# Altium schematic sheet MotorDriver.SchDoc(MotorDriver)
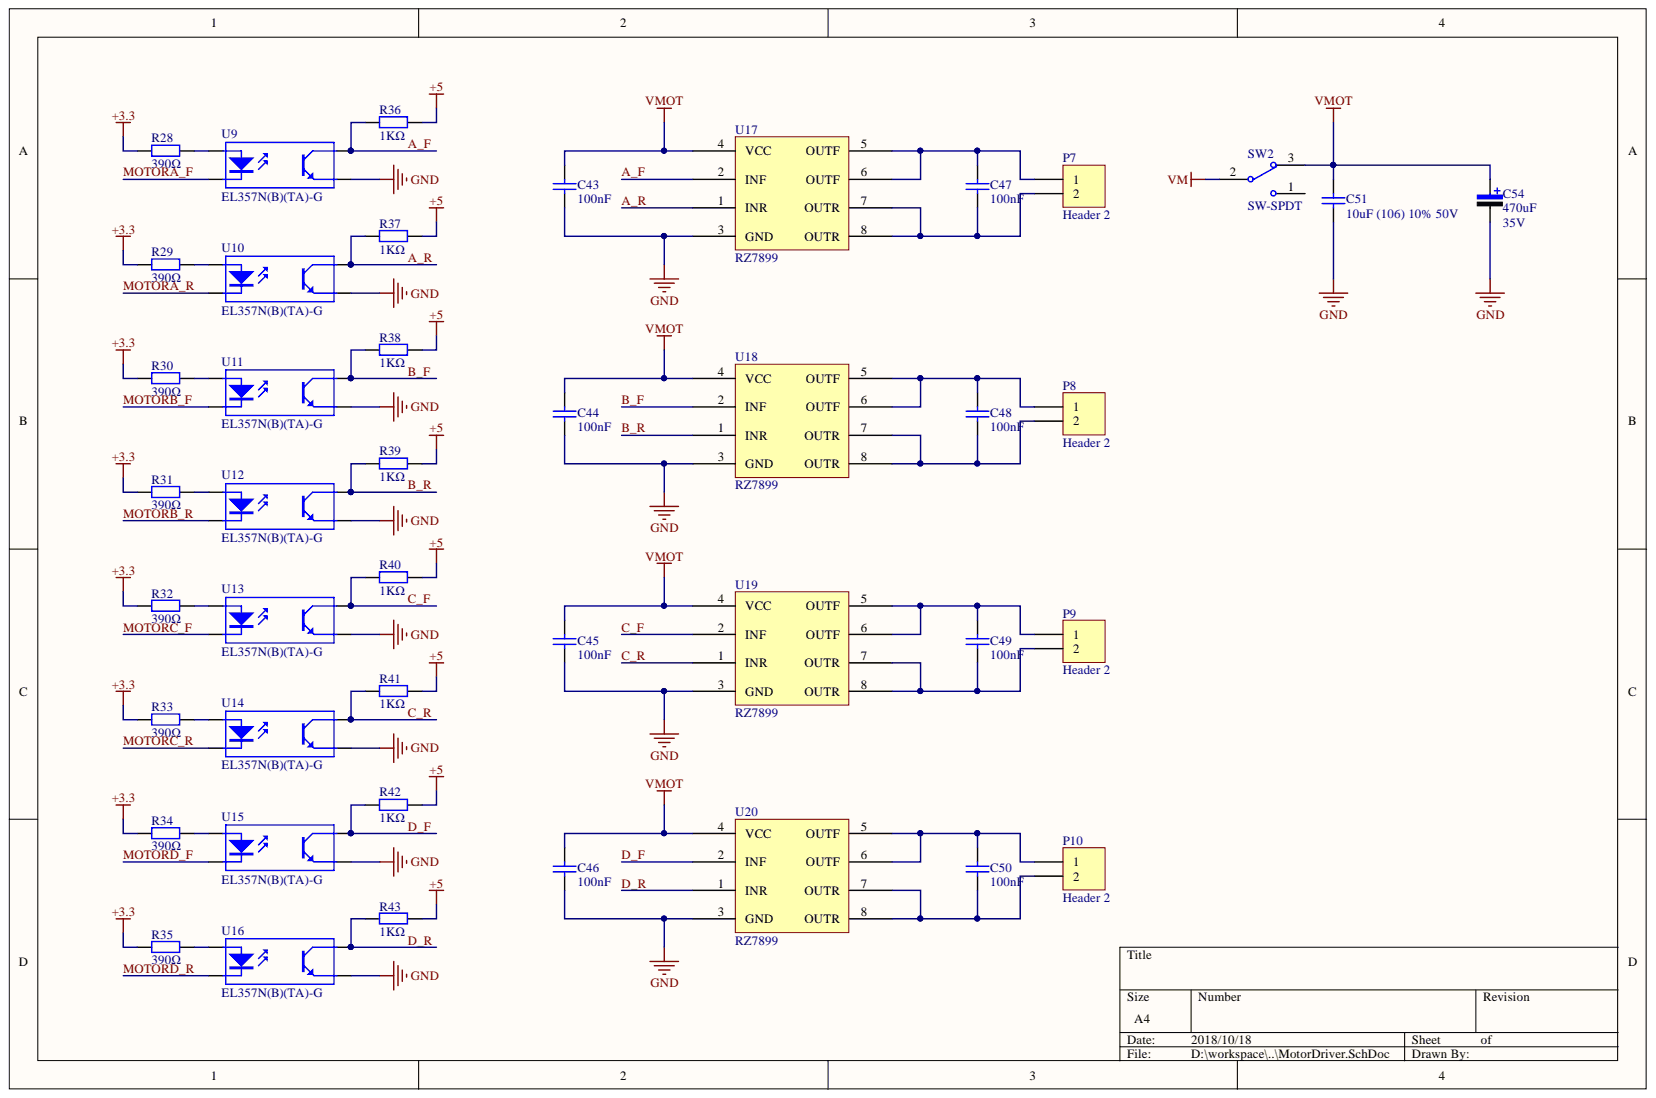
[diagram p0: MotorDriver.SchDoc]

## Parts
C43  100nF  [0603_C]
C44  100nF  [0603_C]
C45  100nF  [0603_C]
C46  100nF  [0603_C]
C47  100nF  [0603_C]
C48  100nF  [0603_C]
C49  100nF  [0603_C]
C50  100nF  [0603_C]
C51  10uF (106) 10% 50V  [1206_C]
C54  470uF 35V  value 470uF  Vol: 35V  [C-RB-S10]
P7  Header 2  [HDR1X2]
P8  Header 2  [HDR1X2]
P9  Header 2  [HDR1X2]
P10  Header 2  [HDR1X2]
R28  390Ω  [0603_R]
R29  390Ω  [0603_R]
R30  390Ω  [0603_R]
R31  390Ω  [0603_R]
R32  390Ω  [0603_R]
R33  390Ω  [0603_R]
R34  390Ω  [0603_R]
R35  390Ω  [0603_R]
R36  1KΩ  [0603_R]
R37  1KΩ  [0603_R]
R38  1KΩ  [0603_R]
R39  1KΩ  [0603_R]
R40  1KΩ  [0603_R]
R41  1KΩ  [0603_R]
R42  1KΩ  [0603_R]
R43  1KΩ  [0603_R]
SW2  SW-SPDT  [SWITCH_A2]
U9  EL357N(B)(TA)-G  [SOP-4_P2.54]
U10  EL357N(B)(TA)-G  [SOP-4_P2.54]
U11  EL357N(B)(TA)-G  [SOP-4_P2.54]
U12  EL357N(B)(TA)-G  [SOP-4_P2.54]
U13  EL357N(B)(TA)-G  [SOP-4_P2.54]
U14  EL357N(B)(TA)-G  [SOP-4_P2.54]
U15  EL357N(B)(TA)-G  [SOP-4_P2.54]
U16  EL357N(B)(TA)-G  [SOP-4_P2.54]
U17  RZ7899  [SOP-8_150mil]
U18  RZ7899  [SOP-8_150mil]
U19  RZ7899  [SOP-8_150mil]
U20  RZ7899  [SOP-8_150mil]

## Nets
+3.3: R28.2 R29.2 R30.2 R31.2 R32.2 R33.2 R34.2 R35.2
+5: R36.1 R37.1 R38.1 R39.1 R40.1 R41.1 R42.1 R43.1
GND: C43.1 C44.1 C45.1 C46.1 C51.1 C54.2 U9.3 U10.3 U11.3 U12.3 U13.3 U14.3 U15.3 U16.3 U17.3(GND) U18.3(GND) U19.3(GND) U20.3(GND)
VM: SW2.2
VMOT: C43.2 C44.2 C45.2 C46.2 C51.2 C54.1 SW2.3 U17.4(VCC) U18.4(VCC) U19.4(VCC) U20.4(VCC)
A_F: R36.2 U9.4 U17.2(INF)
A_R: R37.2 U10.4 U17.1(INR)
B_F: R38.2 U11.4 U18.2(INF)
B_R: R39.2 U12.4 U18.1(INR)
C_F: R40.2 U13.4 U19.2(INF)
C_R: R41.2 U14.4 U19.1(INR)
D_F: R42.2 U15.4 U20.2(INF)
D_R: R43.2 U16.4 U20.1(INR)
MOTORA_F: U9.2
MOTORA_R: U10.2
MOTORB_F: U11.2
MOTORB_R: U12.2
MOTORC_F: U13.2
MOTORC_R: U14.2
MOTORD_F: U15.2
MOTORD_R: U16.2
NetC47_1: C47.1 P7.2 U17.7(OUTR) U17.8(OUTR)
NetC47_2: C47.2 P7.1 U17.5(OUTF) U17.6(OUTF)
NetC48_1: C48.1 P8.2 U18.7(OUTR) U18.8(OUTR)
NetC48_2: C48.2 P8.1 U18.5(OUTF) U18.6(OUTF)
NetC49_1: C49.1 P9.2 U19.7(OUTR) U19.8(OUTR)
NetC49_2: C49.2 P9.1 U19.5(OUTF) U19.6(OUTF)
NetC50_1: C50.1 P10.2 U20.7(OUTR) U20.8(OUTR)
NetC50_2: C50.2 P10.1 U20.5(OUTF) U20.6(OUTF)
NetR28_1: R28.1 U9.1
NetR29_1: R29.1 U10.1
NetR30_1: R30.1 U11.1
NetR31_1: R31.1 U12.1
NetR32_1: R32.1 U13.1
NetR33_1: R33.1 U14.1
NetR34_1: R34.1 U15.1
NetR35_1: R35.1 U16.1

Not connected: SW2 1

## Library data of the parts
C43-C50 = 0603 100nF (104) 10% 50V: 贴片电容; SuppliersPartNumber: C14663; Supplier: LC; Package: 0603; Library Name: Cap.SchLib; ComponentLink1URL: http://www.szlcsc.com/product/details_15331.html; ComponentLink1Description: 供应商链接; Component Kind: Standard
C51 = 1206 10uF (106) 10% 50V: 贴片电容; SuppliersPartNumber: C13585; Supplier: LC; Package: 1206; Library Name: Cap.SchLib; ComponentLink1URL: http://www.szlcsc.com/product/details_14236.html; ComponentLink1Description: 供应商链接; Component Kind: Standard
C54 = Cap Pol: 极性电解电容; Published: 8-Jun-2000; PackageReference: RB7.6-15; LatestRevisionNote: Re-released for DXP Platform.; LatestRevisionDate: 17-Jul-2002; Component Kind: Standard; Publisher: Altium Limited; Library Name: 主集成库.IntLib
P7-P10 = Header 2: Header, 2-Pin; Signal Integrity: Connector; Library Name: Miscellaneous Connectors.IntLib; LatestRevisionNote: Re-released for DXP Platform.; LatestRevisionDate: 17-Jul-2002; Component Kind: Standard; Publisher: Altium Limited
R28-R35 = 0603 390Ω (3900) 1%: 贴片电阻; SuppliersPartNumber: C23151; Supplier: LC; Package: 0603; Library Name: RES.SchLib; ComponentLink1URL: http://www.szlcsc.com/product/details_23878.html; ComponentLink1Description: 供应商链接; Component Kind: Standard
R36-R43 = 0603 1KΩ (1001) 1%: 贴片电阻; SuppliersPartNumber: C21190; Supplier: LC; Package: 0603; Library Name: RES.SchLib; ComponentLink1URL: http://www.szlcsc.com/product/details_21904.html; ComponentLink1Description: 供应商链接; Component Kind: Standard
SW2 = SW-SPDT: 开关; Published: 24-Mar-1999; PackageReference: TL36WW15050; LatestRevisionNote: Schematic revised, PCB Footprint replaced.; LatestRevisionDate: 17-Dec-2003; DatasheetDocument: 05-Dec-2000; ComponentLink1URL: http://www.apem.com; ComponentLink1Description: Manufacturer Link; Component Kind: Standard; Publisher: Altium Limited; Library Name: 主集成库.IntLib
U9-U16 = EL357N(B)(TA)-G_[C6649]: 贴片光耦; SuppliersPartNumber: C6649; Supplier: LC; Package: SOP-4_P2.54; Library Name: IC.SchLib; ComponentLink1URL: http://www.szlcsc.com/product/details_7114.html; ComponentLink1Description: 供应商链接; Component Kind: Standard
U17-U20 = RZ7899: Library Name: SmartCar.SchLib; Component Kind: Standard
